# Altium schematic sheet SCM20260D-Misc.SchDoc(SCM20260D-Misc)
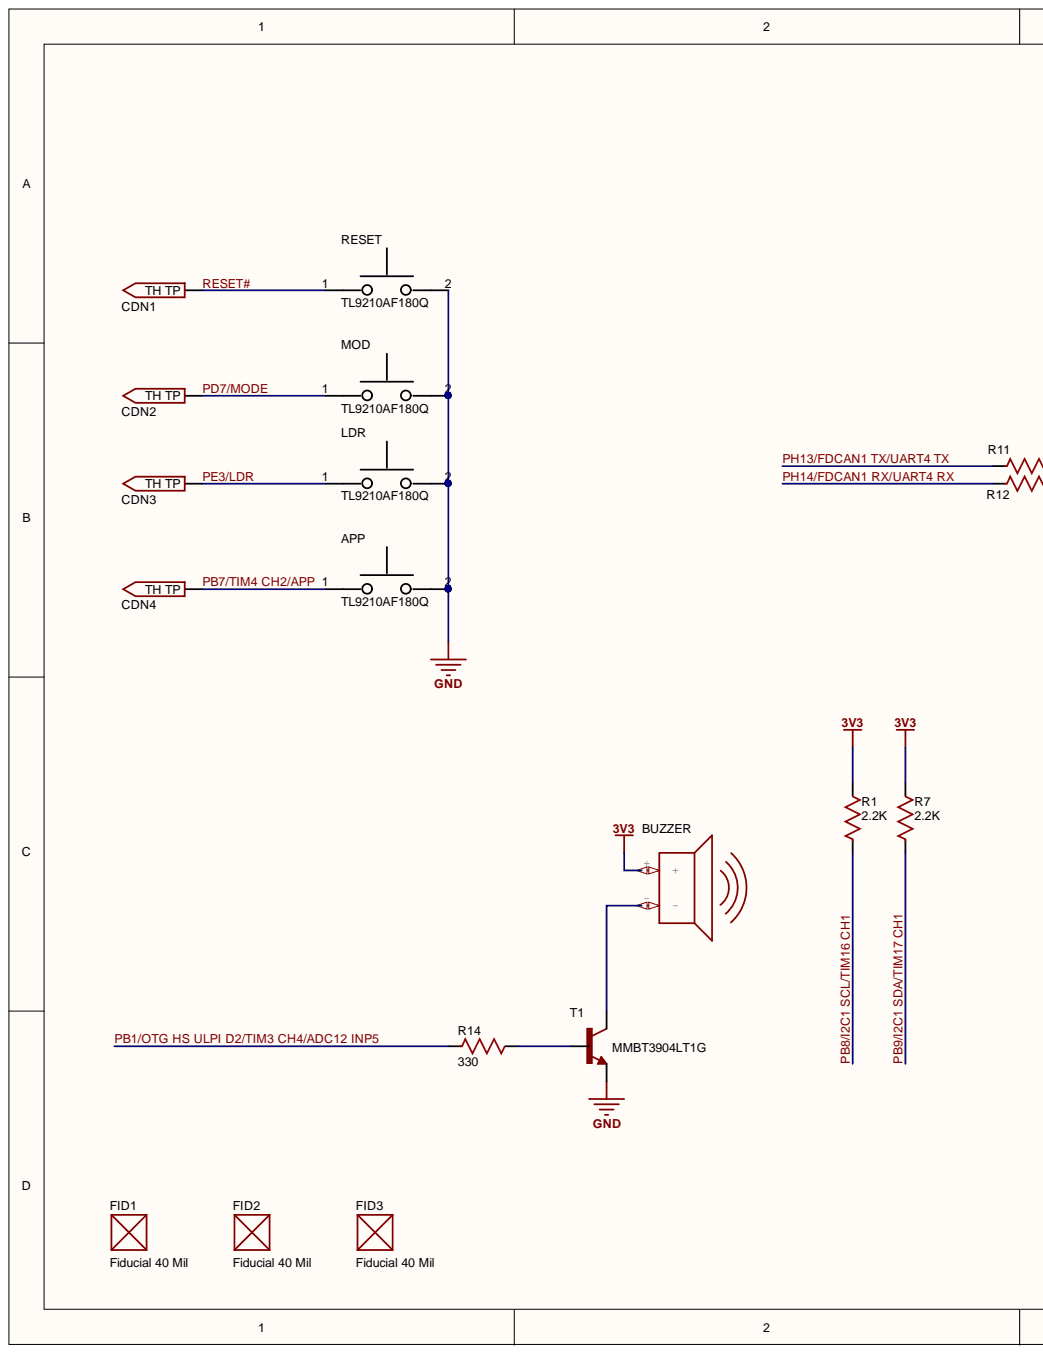
[diagram p0: SCM20260D-Misc.SchDoc, left]
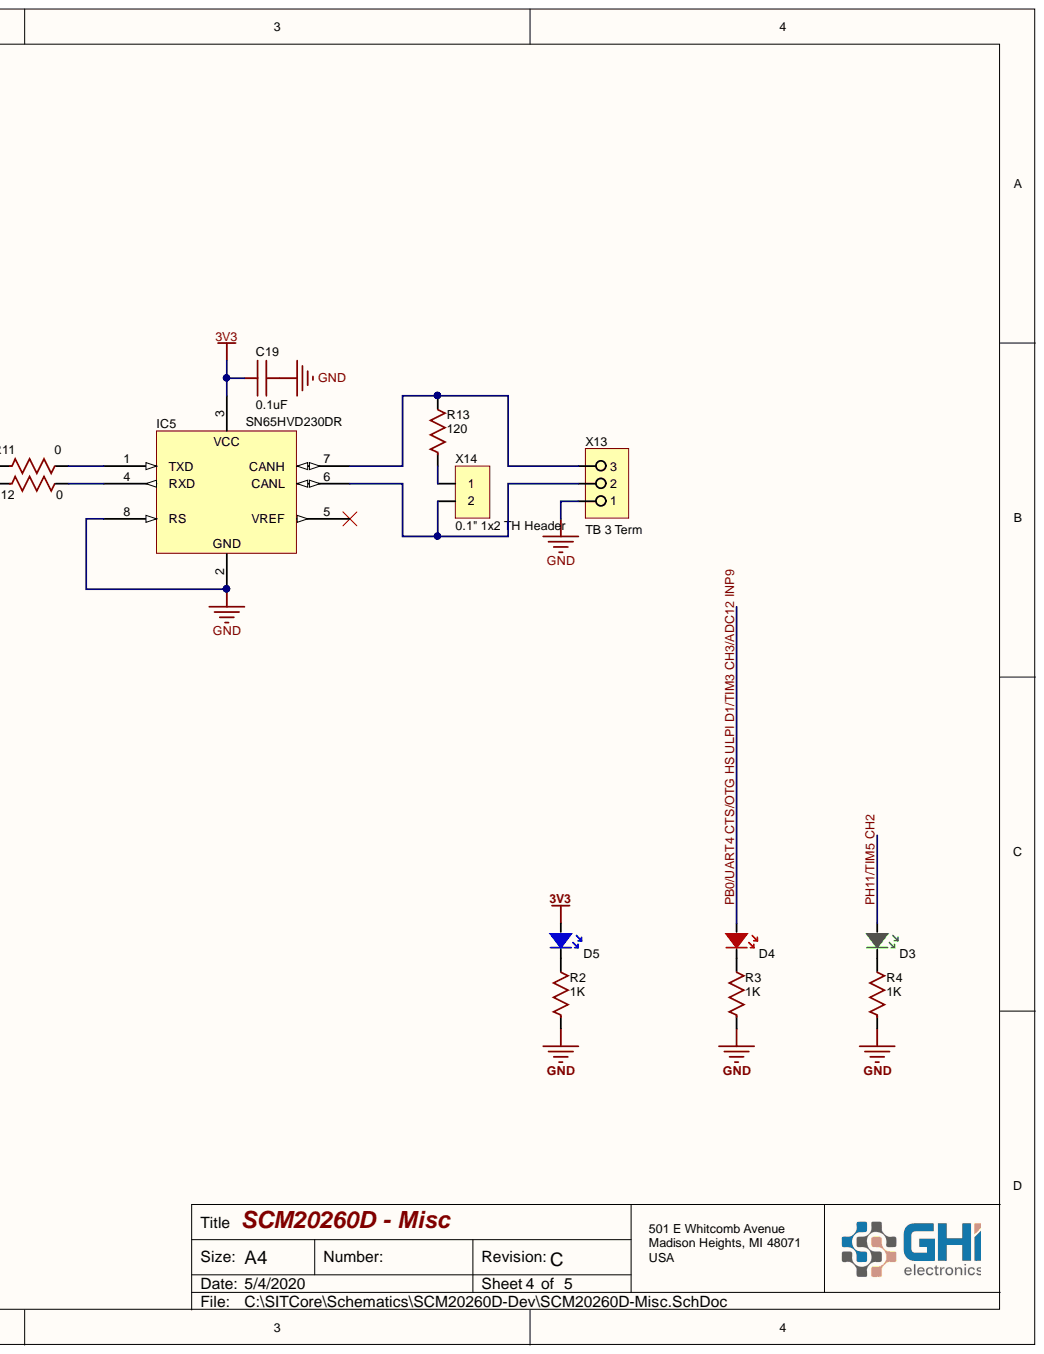
[diagram p1: SCM20260D-Misc.SchDoc, right]

## Parts
APP  value TL9210AF180Q  [Button - TL9210]  (hidden comment: Tactile Switch)
BUZZER  Buzzer - Small  [KLJ-SMD-BUZZER]  (value not drawn)
C19  value 0.1uF  [C0603 (1608 Metric)]  (hidden comment: 0603 Cap 0.1uF)
CDN1  TH Testpad 32mil (32mil ar)  [32mil TH]  (value not drawn)  (no BOM)
CDN2  TH Testpad 32mil (32mil ar)  [32mil TH]  (value not drawn)  (no BOM)
CDN3  TH Testpad 32mil (32mil ar)  [32mil TH]  (value not drawn)  (no BOM)
CDN4  TH Testpad 32mil (32mil ar)  [32mil TH]  (value not drawn)  (no BOM)
D3  0603 LED Green  [0603 LED Green]  (value not drawn)
D4  0603 LED Red  [0603 LED Red]  (value not drawn)
D5  0603 LED Blue  [0603 LED Blue]  (value not drawn)
FID1  Fiducial 40 Mil  [Fiducial 40 Mil]  (no BOM)
FID2  Fiducial 40 Mil  [Fiducial 40 Mil]  (no BOM)
FID3  Fiducial 40 Mil  [Fiducial 40 Mil]  (no BOM)
IC5  SN65HVD230  value SN65HVD230DR  [8-Pin SOIC]
LDR  value TL9210AF180Q  [Button - TL9210]  (hidden comment: Tactile Switch)
MOD  value TL9210AF180Q  [Button - TL9210]  (hidden comment: Tactile Switch)
R1  value 2.2K  [R0603 (1608 Metric)]  (hidden comment: 0603 2.2K)
R2  value 1K  [R0603 (1608 Metric)]  (hidden comment: 0603 1K)
R3  value 1K  [R0603 (1608 Metric)]  (hidden comment: 0603 1K)
R4  value 1K  [R0603 (1608 Metric)]  (hidden comment: 0603 1K)
R7  value 2.2K  [R0603 (1608 Metric)]  (hidden comment: 0603 2.2K)
R11  value 0  [R0603 (1608 Metric)]  (hidden comment: 0603 Res 0)
R12  value 0  [R0603 (1608 Metric)]  (hidden comment: 0603 Res 0)
R13  value 120  [R0603 (1608 Metric)]  (hidden comment: 0603 120)
R14  value 330  [R0603 (1608 Metric)]  (hidden comment: 0603 330)
RESET  value TL9210AF180Q  [Button - TL9210]  (hidden comment: Tactile Switch)
T1  3904  value MMBT3904LT1G  [SOT23]
X13  TB 3 Term  [TB 5mm Pitch 3 Term]
X14  0.1" 1x2 TH Header  [TH 0.1" 1x2 (35mil diameter)]

## Nets
3V3: BUZZER.+ C19.1 D5.2 IC5.3 R1.2 R7.2
GND: APP.2 C19.2 IC5.2 IC5.8(RS) LDR.2 MOD.2 R2.1 R3.1 R4.1 RESET.2 T1.2 X13.1
PB0/UART4 CTS/OTG HS ULPI D1/TIM3 CH3/ADC12 INP9: D4.2
PB1/OTG HS ULPI D2/TIM3 CH4/ADC12 INP5: R14.1
PB7/TIM4 CH2/APP: APP.1 CDN4.1
PB8/I2C1 SCL/TIM16 CH1: R1.1
PB9/I2C1 SDA/TIM17 CH1: R7.1
PD7/MODE: CDN2.1 MOD.1
PE3/LDR: CDN3.1 LDR.1
PH11/TIM5 CH2: D3.2
PH13/FDCAN1 TX/UART4 TX: R11.1
PH14/FDCAN1 RX/UART4 RX: R12.1
RESET#: CDN1.1 RESET.1
NetBUZZER_-: BUZZER.- T1.3
NetD3_1: D3.1 R4.2
NetD4_1: D4.1 R3.2
NetD5_1: D5.1 R2.2
NetIC5_1: IC5.1(TXD) R11.2
NetIC5_4: IC5.4(RXD) R12.2
NetIC5_6: IC5.6(CANL) X13.2 X14.2
NetIC5_7: IC5.7(CANH) R13.2 X13.3
NetR13_1: R13.1 X14.1
NetR14_2: R14.2 T1.1

Not connected: IC5 5(VREF)

## Library data of the parts
APP LDR MOD RESET = Tactile Switch: SPST 50mA @ 12VDC Top Actuated Plunger for Cap SMD,6x6mm Tactile Switches RoHS; Manufacturer: E-Switch; Package: SMD,6x6mm; Mfr.Part #: TL9210AF180Q; LCSC Part #: C273538; Component Kind: Standard; Library Name: GHI.SchLib
BUZZER = KLJ-8530-5027: Piezo Speaker from CHANGZHOU KELIKING ELECTRONICS CO., LTD; Value: KLJ-8530-5027; PartNo: KLJ-8530-5027; Component Kind: Standard; Library Name: GHI.SchLib
C19 = 0603 Cap 0.1uF: 0.1uF ±5% 50V X7R 0603 Multilayer Ceramic Capacitors MLCC - SMD/SMT RoHS; Mfr.Part #: CL10B104JB8NNNC; Voltage: 50V; Tolerance: ±5%; Package: 0603; LCSC Part #: C24452; Digikey: 1276-1033-1-ND; Component Kind: Standard; Category: Ceramic; Capacitance: 0.1uF; Library Name: GHI-Passives.SchLib
CDN1-CDN4 = TH Testpad 32mil (32mil ar): TH Testpad 32mil (32mil annular ring); Library Name: GHI.SchLib
D3 = 0603 LED Green: Green 567.5~576.5nm 0603 Light Emitting Diodes (LED) RoHS; Mfr.Part #: LTST-C193KGKT-5A; Manufacturer: Lite-On; Value: 0603 LED Green; Package: 0603; LCSC Part #: C125102; Component Kind: Standard; Color: Green; Library Name: GHI-Opto.SchLib
D4 = 0603 LED Red: Red 631nm 0603 Light Emitting Diodes (LED) RoHS; Mfr.Part #: LTST-C193KRKT-5A; Manufacturer: Lite-On; Value: 0603 LED Red; Package: 0603; LCSC Part #: C157741; Component Kind: Standard; Color: Red; Library Name: GHI-Opto.SchLib
D5 = 0603 LED Blue: Blue 468nm 0603 Light Emitting Diodes (LED) RoHS; Mfr.Part #: LTST-C193TBKT-5A; Manufacturer: Lite-On; Value: 0603 LED Blue; Package: 0603; LCSC Part #: C125103; Component Kind: Standard; Color: Blue; Library Name: GHI-Opto.SchLib
IC5 = SN65HVD23x: TI 3.3-V CAN Bus Transceivers (SN65HVD23x ); Manufacturer: Texas Instruments; Package: SOIC-8_150mil; Mfr.Part #: SN65HVD230DR; LCSC Part #: C12084; Component Kind: Standard; Library Name: GHI.SchLib
R1 R7 = 0603 Res 2.2K: 2.2K ±1% 1/10W ±100ppm/℃ 0603 Chip Resistor - Surface Mount RoHS; Mfr.Part #: RC0603FR-072K2L; Manufacturer: YAGEO; Voltage Rating: *V; Resistance Tolerance: ±1%; Resistance: 2.2K; Power Rating: 1/10W; Package: 0603; LCSC Part #: C114662; Component Kind: Standard; Library Name: GHI-Passives.SchLib
R2-R4 = 0603 Res 1K: 1K ±5% 1/10W ±100ppm/℃ 0603 Chip Resistor - Surface Mount RoHS; Mfr.Part #: WR06X102 JTL; Manufacturer: Walsin Tech Corp; Voltage Rating: *V; Resistance Tolerance: ±5%; Resistance: 1K; Power Rating: 1/10W; Package: 0603; LCSC Part #: C384295; Component Kind: Standard; Library Name: GHI-Passives.SchLib
R11 R12 = 0603 Res 0: ±5% 1/10W 0603 Chip Resistor - Surface Mount RoHS; Mfr.Part #: RC0603JR-070RL; Manufacturer: YAGEO; Voltage Rating: *V; Resistance Tolerance: ±5%; Resistance: 0; Power Rating: 1/10W; Package: 0603; LCSC Part #: C95177; Component Kind: Standard; Library Name: GHI-Passives.SchLib
R13 = 0603 Res 120: 120 ±1% 1/10W ±100ppm/℃ 0603 Chip Resistor - Surface Mount RoHS; Mfr.Part #: RC0603FR-07120RL; Manufacturer: YAGEO; Voltage Rating: *V; Resistance Tolerance: ±1%; Resistance: 120; Power Rating: 1/10W; Package: 0603; LCSC Part #: C114640; Component Kind: Standard; Library Name: GHI-Passives.SchLib
R14 = 0603 Res 330: 330 ±5% 1/10W ±100ppm/℃ 0603 Chip Resistor - Surface Mount RoHS; Mfr.Part #: WR06X331 JTL; Manufacturer: Walsin Tech Corp; Voltage Rating: *V; Resistance Tolerance: ±5%; Resistance: 330; Power Rating: 1/10W; Package: 0603; LCSC Part #: C384242; Component Kind: Standard; Library Name: GHI-Passives.SchLib
T1 = MMBT3904LT1G: NPN 200mA 40V 300mW SOT-23(SOT-23-3) Transistors (NPN/PNP) RoHS; Manufacturer: ON Semicon; Package: SOT-23(SOT-23-3); Mfr.Part #: MMBT3904LT1G; LCSC Part #: C81464; Component Kind: Standard; Library Name: GHI-Semiconductors.SchLib
X13 = TB 3 Term 5mm Pitch: Terminal block 3 terminals 5mm pitch; Value: TB 3 Term 5mm Pitch; Component Kind: Standard; Library Name: GHI Sch.SchLib
X14 = 1x2 Pin 0.1" TH: Value: 1x2 Pin 0.1" TH; Description: 1x2 Pin 0.1" TH; Component Kind: Standard; Library Name: GHI Headers.SchLib
FID1-FID3 = Fiducial 40 Mil: Library Name: GHI.SchLib
